# Altium schematic sheet x-IMU3-SA-TRRS.SchDoc
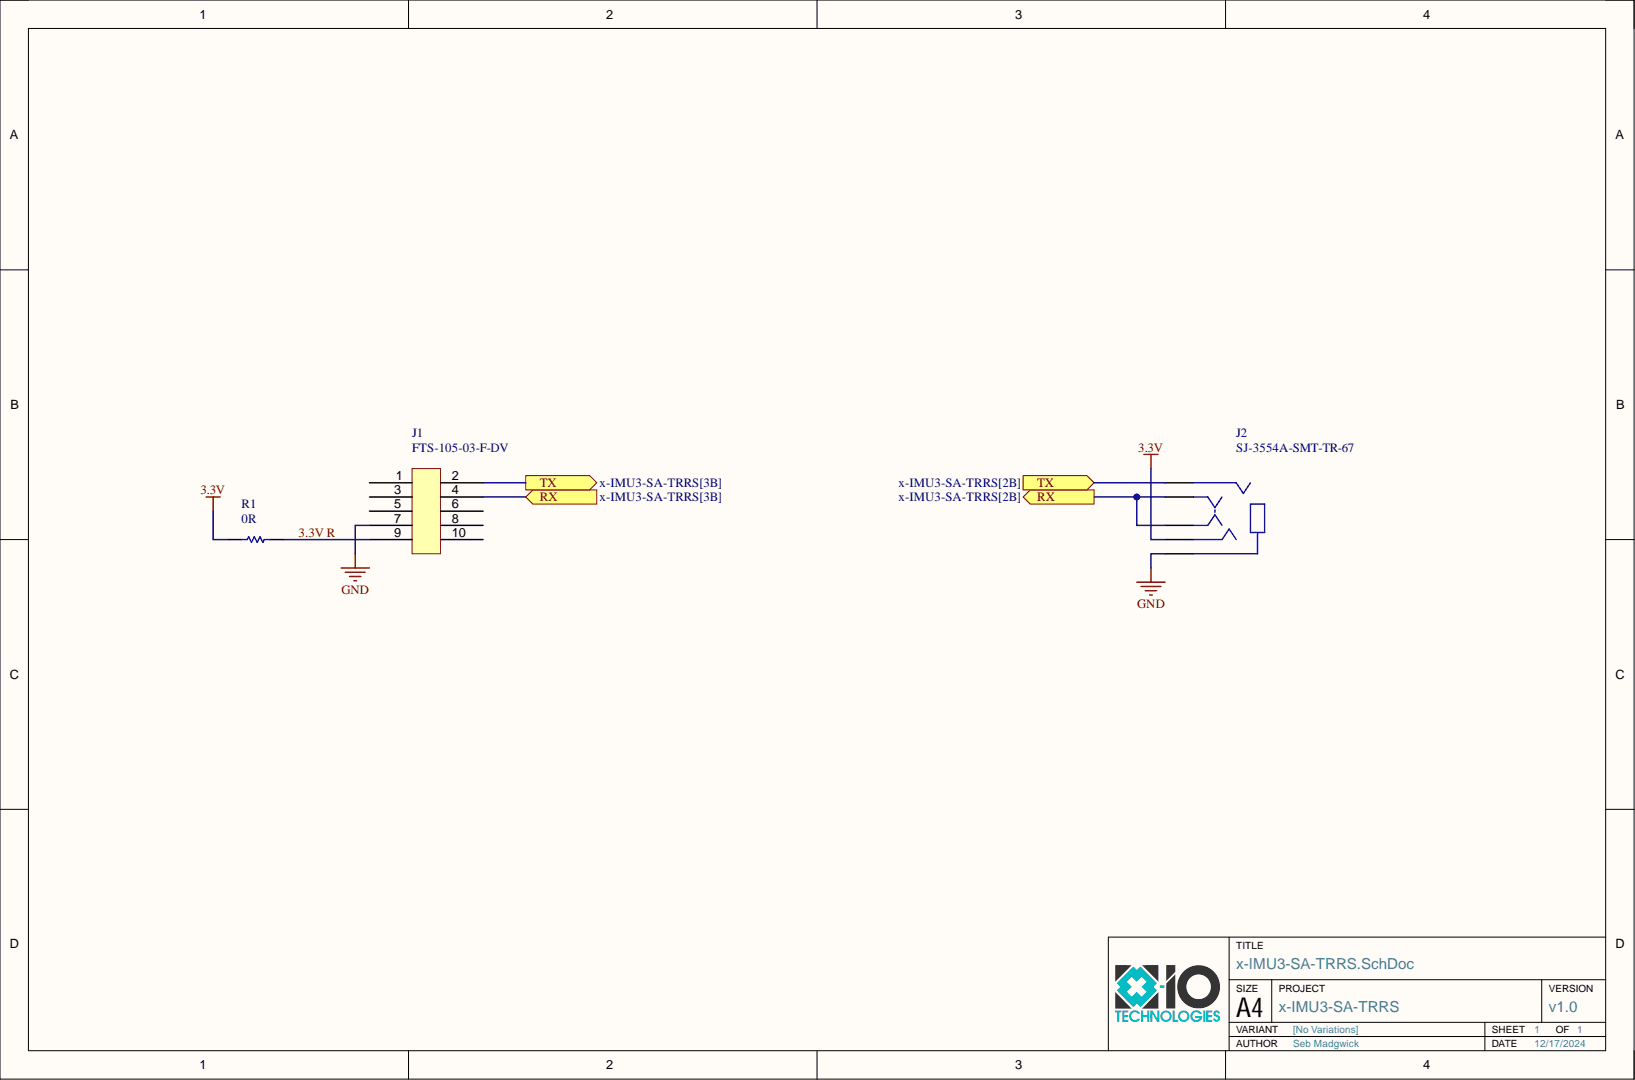
[diagram p0: x-IMU3-SA-TRRS.SchDoc]

## Parts
J1  FTS-105-03-F-DV  [FTS-105-03-F-DV]
J2  SJ-3554A-SMT-TR-67  [SJ-3554A-SMT-TR-67]
R1  0R  [Resistor 0603]

## Ports
RX, TX

## Nets
3.3V: J2.3 R1.1
GND: J1.7 J2.1
3.3V R: J1.9 R1.2
RX: J1.4 J2.2 J2.5 ; port RX
TX: J1.2 J2.4 ; port TX

Not connected: J1 1 3 5 6 8 10

## Library data of the parts
R1 = Resistor: Component Kind: Standard; Library Name: x-io.SchLib
J1 = FTS-105-03-F-DV: Component Kind: Standard; Library Name: x-io.SchLib
J2 = SJ-3554A-SMT-TR-67: Component Kind: Standard; Library Name: x-io.SchLib
